# Altium schematic sheet 03-10715_Rev_0.1_Sheet_2.SchDoc
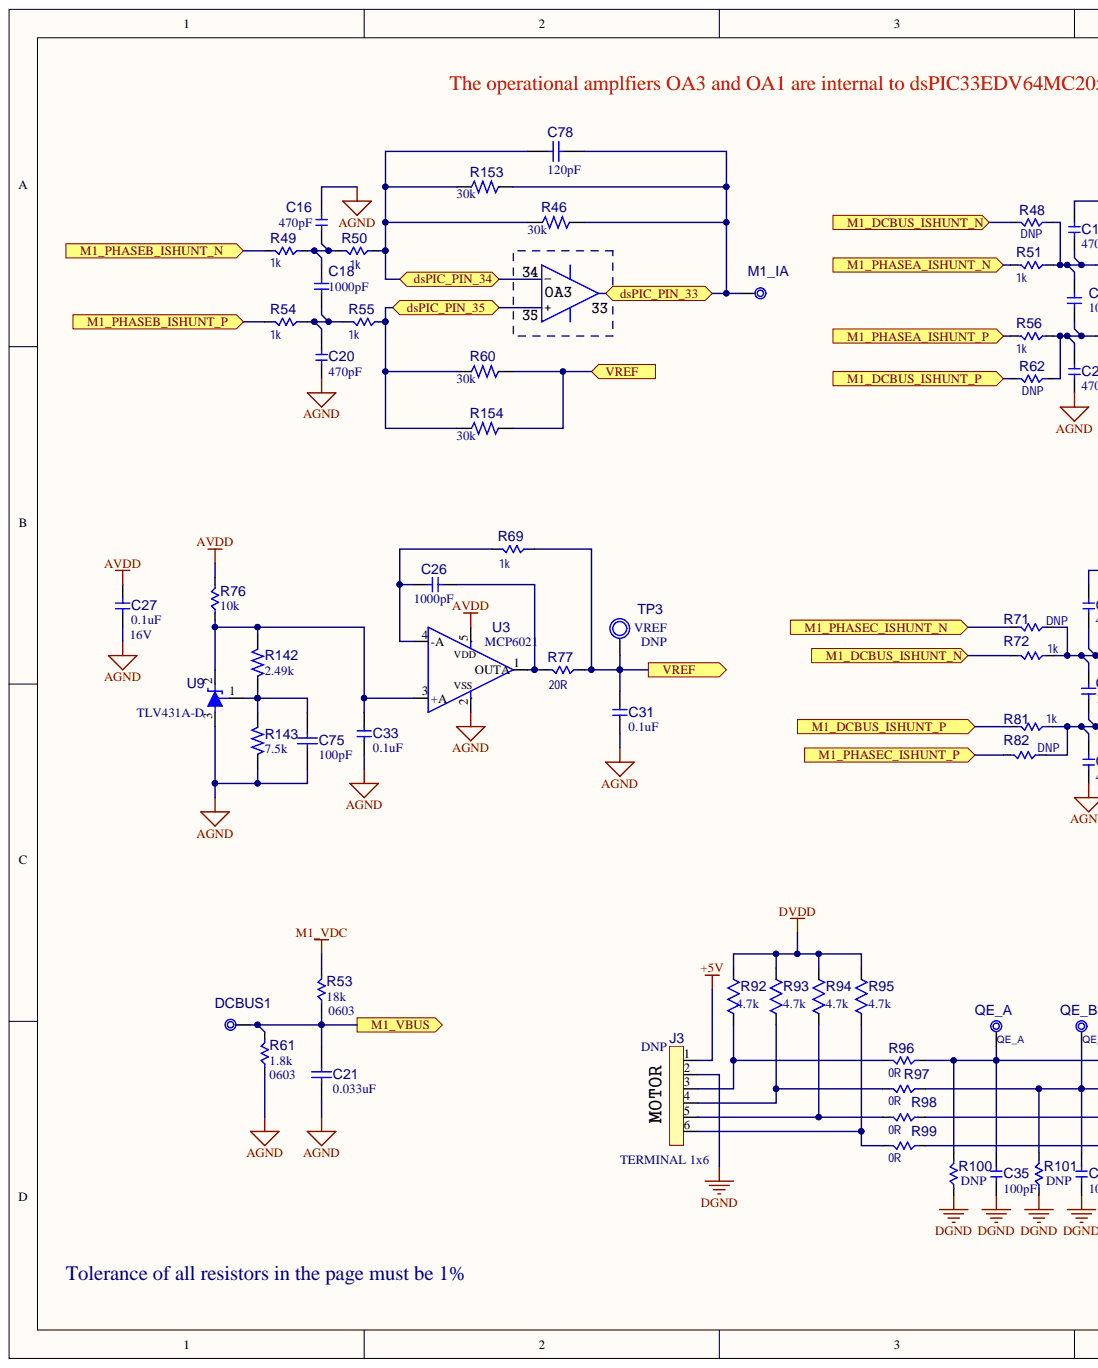
[diagram p0: 03-10715_Rev_0.1_Sheet_2.SchDoc, left]
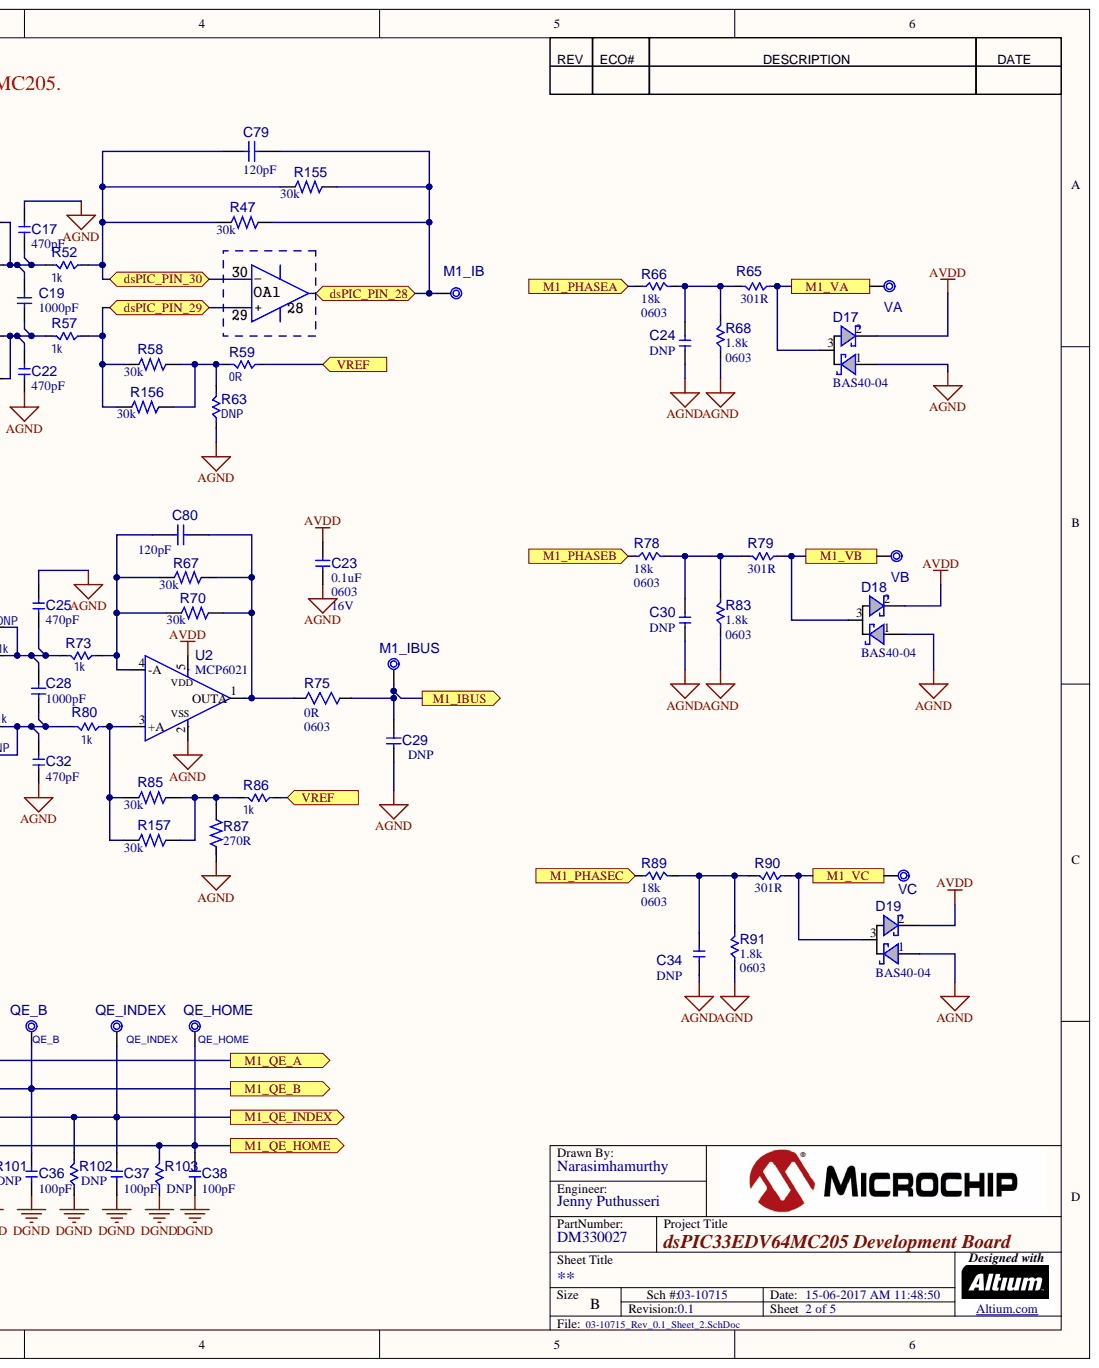
[diagram p1: 03-10715_Rev_0.1_Sheet_2.SchDoc, right]

## Parts
C16  value 470pF  [CAP_C_0603]  (hidden comment: Capacitor NP)
C17  value 470pF  [CAP_C_0603]  (hidden comment: Capacitor NP)
C18  value 1000pF  [CAP_C_0603]  (hidden comment: Capacitor NP)
C19  value 1000pF  [CAP_C_0603]  (hidden comment: Capacitor NP)
C20  value 470pF  [CAP_C_0603]  (hidden comment: Capacitor NP)
C21  value 0.033uF  [CAP_C_0603]  (hidden comment: Capacitor NP)
C22  value 470pF  [CAP_C_0603]  (hidden comment: Capacitor NP)
C23  value 0.1uF  Package: 0603  Voltage: 16V  [CAP_C_0603]  (hidden comment: Capacitor NP)
C24  Package: 0603  Populated: DNP  [CAP_C_0603]  (hidden comment: Capacitor NP)
C25  value 470pF  [CAP_C_0603]  (hidden comment: Capacitor NP)
C26  value 1000pF  [CAP_C_0603]  (hidden comment: Capacitor NP)
C27  value 0.1uF  Voltage: 16V  [CAP_C_0603]  (hidden comment: Capacitor NP)
C28  value 1000pF  [CAP_C_0603]  (hidden comment: Capacitor NP)
C29  Populated: DNP  [CAP_C_0603]  (hidden comment: Capacitor NP)
C30  Package: 0603  Populated: DNP  [CAP_C_0603]  (hidden comment: Capacitor NP)
C31  value 0.1uF  [CAP_C_0603]  (hidden comment: Capacitor NP)
C32  value 470pF  [CAP_C_0603]  (hidden comment: Capacitor NP)
C33  value 0.1uF  [CAP_C_0603]  (hidden comment: Capacitor NP)
C34  Populated: DNP  [CAP_C_0603]  (hidden comment: Capacitor NP)
C35  value 100pF  [CAP_C_0603]  (hidden comment: Capacitor NP)
C36  value 100pF  [CAP_C_0603]  (hidden comment: Capacitor NP)
C37  value 100pF  [CAP_C_0603]  (hidden comment: Capacitor NP)
C38  value 100pF  [CAP_C_0603]  (hidden comment: Capacitor NP)
C75  value 100pF  [CAP_C_0603]  (hidden comment: Capacitor NP)
C78  value 120pF  [CAP_C_0603]  (hidden comment: Capacitor NP)
C79  value 120pF  [CAP_C_0603]  (hidden comment: Capacitor NP)
C80  value 120pF  [CAP_C_0603]  (hidden comment: Capacitor NP)
D17  value BAS40-04  [SOT-23-3]  (hidden comment: Diode Schottky Dual AK)
D18  value BAS40-04  [SOT-23-3]  (hidden comment: Diode Schottky Dual AK)
D19  value BAS40-04  [SOT-23-3]  (hidden comment: Diode Schottky Dual AK)
DCBUS1  Test Point No BOM  [TP_1.2x0.7]  (value not drawn)  (no BOM)
J3  value TERMINAL 1x6  Populated: DNP  [CON_TERMINAL_5_1x6_TH_RA_OST_ED5006DS]  (hidden comment: Header 1x6)
M1_IA  M1_IA  [TP_1.2x0.7]  (no BOM)
M1_IB  M1_IB  [TP_1.2x0.7]  (no BOM)
M1_IBUS  Test Point No BOM  [TP_1.2x0.7]  (value not drawn)  (no BOM)
QE_A  QE_A  [TP_1.2x0.7]  (no BOM)
QE_B  QE_B  [TP_1.2x0.7]  (no BOM)
QE_HOME  QE_HOME  [TP_1.2x0.7]  (no BOM)
QE_INDEX  QE_INDEX  [TP_1.2x0.7]  (no BOM)
R46  value 30k  [RES_C_0603]  (hidden comment: Resistor)
R47  value 30k  [RES_C_0603]  (hidden comment: Resistor)
R48  Populated: DNP  [RES_C_0603]  (hidden comment: RC_0805)
R49  value 1k  [RES_C_0603]  (hidden comment: RC_0805)
R50  value 1k  [RES_C_0603]  (hidden comment: RC_0805)
R51  value 1k  [RES_C_0603]  (hidden comment: RC_0805)
R52  value 1k  [RES_C_0603]  (hidden comment: RC_0805)
R53  value 18k  Package: 0603  [RES_C_0603]  (hidden comment: Resistor)
R54  value 1k  [RES_C_0603]  (hidden comment: RC_0805)
R55  value 1k  [RES_C_0603]  (hidden comment: RC_0805)
R56  value 1k  [RES_C_0603]  (hidden comment: RC_0805)
R57  value 1k  [RES_C_0603]  (hidden comment: RC_0805)
R58  value 30k  [RES_C_0603]  (hidden comment: Resistor)
R59  value 0R  [RES_C_0603]  (hidden comment: RC_0805_No Load)
R60  value 30k  [RES_C_0603]  (hidden comment: Resistor)
R61  value 1.8k  Package: 0603  [RES_C_0603]  (hidden comment: Resistor)
R62  value 1k  Populated: DNP  [RES_C_0603]  (hidden comment: RC_0805)
R63  Populated: DNP  [RES_C_0603]  (hidden comment: RC_0805_No Load)
R65  value 301R  [RES_C_0603]  (hidden comment: Resistor)
R66  value 18k  [RES_C_0603]  (hidden comment: Resistor)
R67  value 30k  [RES_C_0603]  (hidden comment: Resistor)
R68  value 1.8k  Package: 0603  [RES_C_0603]  (hidden comment: Resistor)
R69  value 1k  [RES_C_0603]  (hidden comment: Resistor)
R70  value 30k  [RES_C_0603]  (hidden comment: Resistor)
R71  Populated: DNP  [RES_C_0603]  (hidden comment: RC_0805)
R72  value 1k  [RES_C_0603]  (hidden comment: RC_0805)
R73  value 1k  [RES_C_0603]  (hidden comment: RC_0805)
R75  value 0R  Package: 0603  [RES_C_0603]  (hidden comment: RC_0805)
R76  value 10k  [RES_C_0603]  (hidden comment: Resistor)
R77  value 20R  [RES_C_0603]  (hidden comment: Resistor)
R78  value 18k  Package: 0603  [RES_C_0603]  (hidden comment: Resistor)
R79  value 301R  [RES_C_0603]  (hidden comment: Resistor)
R80  value 1k  [RES_C_0603]  (hidden comment: RC_0805)
R81  value 1k  [RES_C_0603]  (hidden comment: RC_0805)
R82  Populated: DNP  [RES_C_0603]  (hidden comment: RC_0805)
R83  value 1.8k  Package: 0603  [RES_C_0603]  (hidden comment: Resistor)
R85  value 30k  [RES_C_0603]  (hidden comment: Resistor)
R86  value 1k  [RES_C_0603]  (hidden comment: RC_0805)
R87  value 270R  [RES_C_0603]  (hidden comment: Resistor)
R89  value 18k  Package: 0603  [RES_C_0603]  (hidden comment: Resistor)
R90  value 301R  [RES_C_0603]  (hidden comment: Resistor)
R91  value 1.8k  Package: 0603  [RES_C_0603]  (hidden comment: Resistor)
R92  value 4.7k  [RES_C_0603]  (hidden comment: Resistor)
R93  value 4.7k  [RES_C_0603]  (hidden comment: Resistor)
R94  value 4.7k  [RES_C_0603]  (hidden comment: Resistor)
R95  value 4.7k  [RES_C_0603]  (hidden comment: Resistor)
R96  value 0R  [RES_C_0603]  (hidden comment: Resistor)
R97  value 0R  [RES_C_0603]  (hidden comment: Resistor)
R98  value 0R  [RES_C_0603]  (hidden comment: Resistor)
R99  value 0R  [RES_C_0603]  (hidden comment: Resistor)
R100  Populated: DNP  [RES_C_0603]  (hidden comment: Resistor)
R101  Populated: DNP  [RES_C_0603]  (hidden comment: Resistor)
R102  Populated: DNP  [RES_C_0603]  (hidden comment: Resistor)
R103  Populated: DNP  [RES_C_0603]  (hidden comment: Resistor)
R142  value 2.49k  [RES_C_0603]  (hidden comment: Resistor)
R143  value 7.5k  [RES_C_0603]  (hidden comment: Resistor)
R153  value 30k  [RES_C_0603]  (hidden comment: Resistor)
R154  value 30k  [RES_C_0603]  (hidden comment: Resistor)
R155  value 30k  [RES_C_0603]  (hidden comment: Resistor)
R156  value 30k  [RES_C_0603]  (hidden comment: Resistor)
R157  value 30k  [RES_C_0603]  (hidden comment: Resistor)
TP3  VREF  Populated: DNP  [CON_TP_TH_V_WHT_KEC_5012]
U2  value MCP6021  [SOT-23-5]  (hidden comment: MCP6XX1_6 SOT-23-5_SC-70-5)
U3  value MCP6021  [SOT-23-5]  (hidden comment: MCP6XX1_6 SOT-23-5_SC-70-5)
U9  value TLV431A-D  [SOT-23-3]  (hidden comment: TLV431 SOT-23-3)
VA  Test Point No BOM  [TP_1.2x0.7]  (value not drawn)  (no BOM)
VB  Test Point No BOM  [TP_1.2x0.7]  (value not drawn)  (no BOM)
VC  Test Point No BOM  [TP_1.2x0.7]  (value not drawn)  (no BOM)

## Ports
dsPIC_PIN_28, dsPIC_PIN_29, dsPIC_PIN_30, dsPIC_PIN_33, dsPIC_PIN_34, dsPIC_PIN_35, M1_DCBUS_ISHUNT_N, M1_DCBUS_ISHUNT_P, M1_IBUS, M1_PHASEA, M1_PHASEA_ISHUNT_N, M1_PHASEA_ISHUNT_P, M1_PHASEB, M1_PHASEB_ISHUNT_N, M1_PHASEB_ISHUNT_P, M1_PHASEC, M1_PHASEC_ISHUNT_N, M1_PHASEC_ISHUNT_P, M1_QE_A, M1_QE_B, M1_QE_HOME, M1_QE_INDEX, M1_VA, M1_VB, M1_VBUS, M1_VC, VREF

## Nets
+5V: J3.1
AGND: C16.1 C17.1 C20.2 C21.1 C22.2 C23.1 C24.2 C25.1 C27.1 C29.1 C30.2 C31.1 C32.2 C33.1 C34.2 C75.1 D17.1 D18.1 D19.1 R61.2 R63.2 R68.2 R83.2 R87.1 R91.2 R143.1 U2.2 U3.2 U9.3
AVDD: C23.2 C27.2 D17.2 D18.2 D19.2 R76.1 U2.5 U3.5
DGND: C35.2 C36.2 C37.2 C38.2 J3.2 R100.2 R101.2 R102.2 R103.2
DVDD: R92.1 R93.1 R94.1 R95.1
M1_VDC: R53.1
dsPIC_PIN_28: C79.2 M1_IB.1 R47.2 R155.2 ; port dsPIC_PIN_28
dsPIC_PIN_29: R57.2 R58.1 R156.1 ; port dsPIC_PIN_29
dsPIC_PIN_30: C79.1 R47.1 R52.2 R155.1 ; port dsPIC_PIN_30
dsPIC_PIN_33: C78.2 M1_IA.1 R46.2 R153.2 ; port dsPIC_PIN_33
dsPIC_PIN_34: C78.1 R46.1 R50.2 R153.1 ; port dsPIC_PIN_34
dsPIC_PIN_35: R55.2 R60.1 R154.1 ; port dsPIC_PIN_35
M1_DCBUS_ISHUNT_N: R48.1 R72.1 ; port M1_DCBUS_ISHUNT_N
M1_DCBUS_ISHUNT_P: R62.1 R81.1 ; port M1_DCBUS_ISHUNT_P
M1_IBUS: C29.2 M1_IBUS.1 R75.2 ; port M1_IBUS
M1_PHASEA: R66.2 ; port M1_PHASEA
M1_PHASEA_ISHUNT_N: R51.1 ; port M1_PHASEA_ISHUNT_N
M1_PHASEA_ISHUNT_P: R56.1 ; port M1_PHASEA_ISHUNT_P
M1_PHASEB: R78.2 ; port M1_PHASEB
M1_PHASEB_ISHUNT_N: R49.1 ; port M1_PHASEB_ISHUNT_N
M1_PHASEB_ISHUNT_P: R54.1 ; port M1_PHASEB_ISHUNT_P
M1_PHASEC: R89.2 ; port M1_PHASEC
M1_PHASEC_ISHUNT_N: R71.1 ; port M1_PHASEC_ISHUNT_N
M1_PHASEC_ISHUNT_P: R82.1 ; port M1_PHASEC_ISHUNT_P
M1_QE_A: C35.1 QE_A.1 R96.2 R100.1 ; port M1_QE_A
M1_QE_B: C36.1 QE_B.1 R97.2 R101.1 ; port M1_QE_B
M1_QE_HOME: C38.1 QE_HOME.1 R99.2 R103.1 ; port M1_QE_HOME
M1_QE_INDEX: C37.1 QE_INDEX.1 R98.2 R102.1 ; port M1_QE_INDEX
M1_VA: D17.3 R65.2 VA.1 ; port M1_VA
M1_VB: D18.3 R79.2 VB.1 ; port M1_VB
M1_VBUS: C21.2 DCBUS1.1 R53.2 R61.1 ; port M1_VBUS
M1_VC: D19.3 R90.2 VC.1 ; port M1_VC
VREF: C31.2 R59.2 R60.2 R69.2 R77.2 R86.2 R154.2 TP3.1 ; port VREF
NetC16_2: C16.2 C18.2 R49.2 R50.1
NetC17_2: C17.2 C19.2 R48.2 R51.2 R52.1
NetC18_1: C18.1 C20.1 R54.2 R55.1
NetC19_1: C19.1 C22.1 R56.2 R57.1 R62.2
NetC24_1: C24.1 R65.1 R66.1 R68.1
NetC25_2: C25.2 C28.2 R71.2 R72.2 R73.1
NetC26_1: C26.1 R69.1 U3.4(-A)
NetC26_2: C26.2 R77.1 U3.1(OUTA)
NetC28_1: C28.1 C32.1 R80.1 R81.2 R82.2
NetC30_1: C30.1 R78.1 R79.1 R83.1
NetC33_2: C33.2 R76.2 R142.2 U3.3(+A) U9.2
NetC34_1: C34.1 R89.1 R90.1 R91.1
NetC75_2: C75.2 R142.1 R143.2 U9.1
NetC80_1: C80.1 R67.1 R70.1 R73.2 U2.4(-A)
NetC80_2: C80.2 R67.2 R70.2 R75.1 U2.1(OUTA)
NetJ3_3: J3.3 R92.2 R96.1
NetJ3_4: J3.4 R93.2 R97.1
NetJ3_5: J3.5 R94.2 R98.1
NetJ3_6: J3.6 R95.2 R99.1
NetR58_2: R58.2 R59.1 R63.1 R156.2
NetR80_2: R80.2 R85.1 R157.1 U2.3(+A)
NetR85_2: R85.2 R86.1 R87.2 R157.2
N00125:
